# Altium schematic sheet cree_module.SchDoc
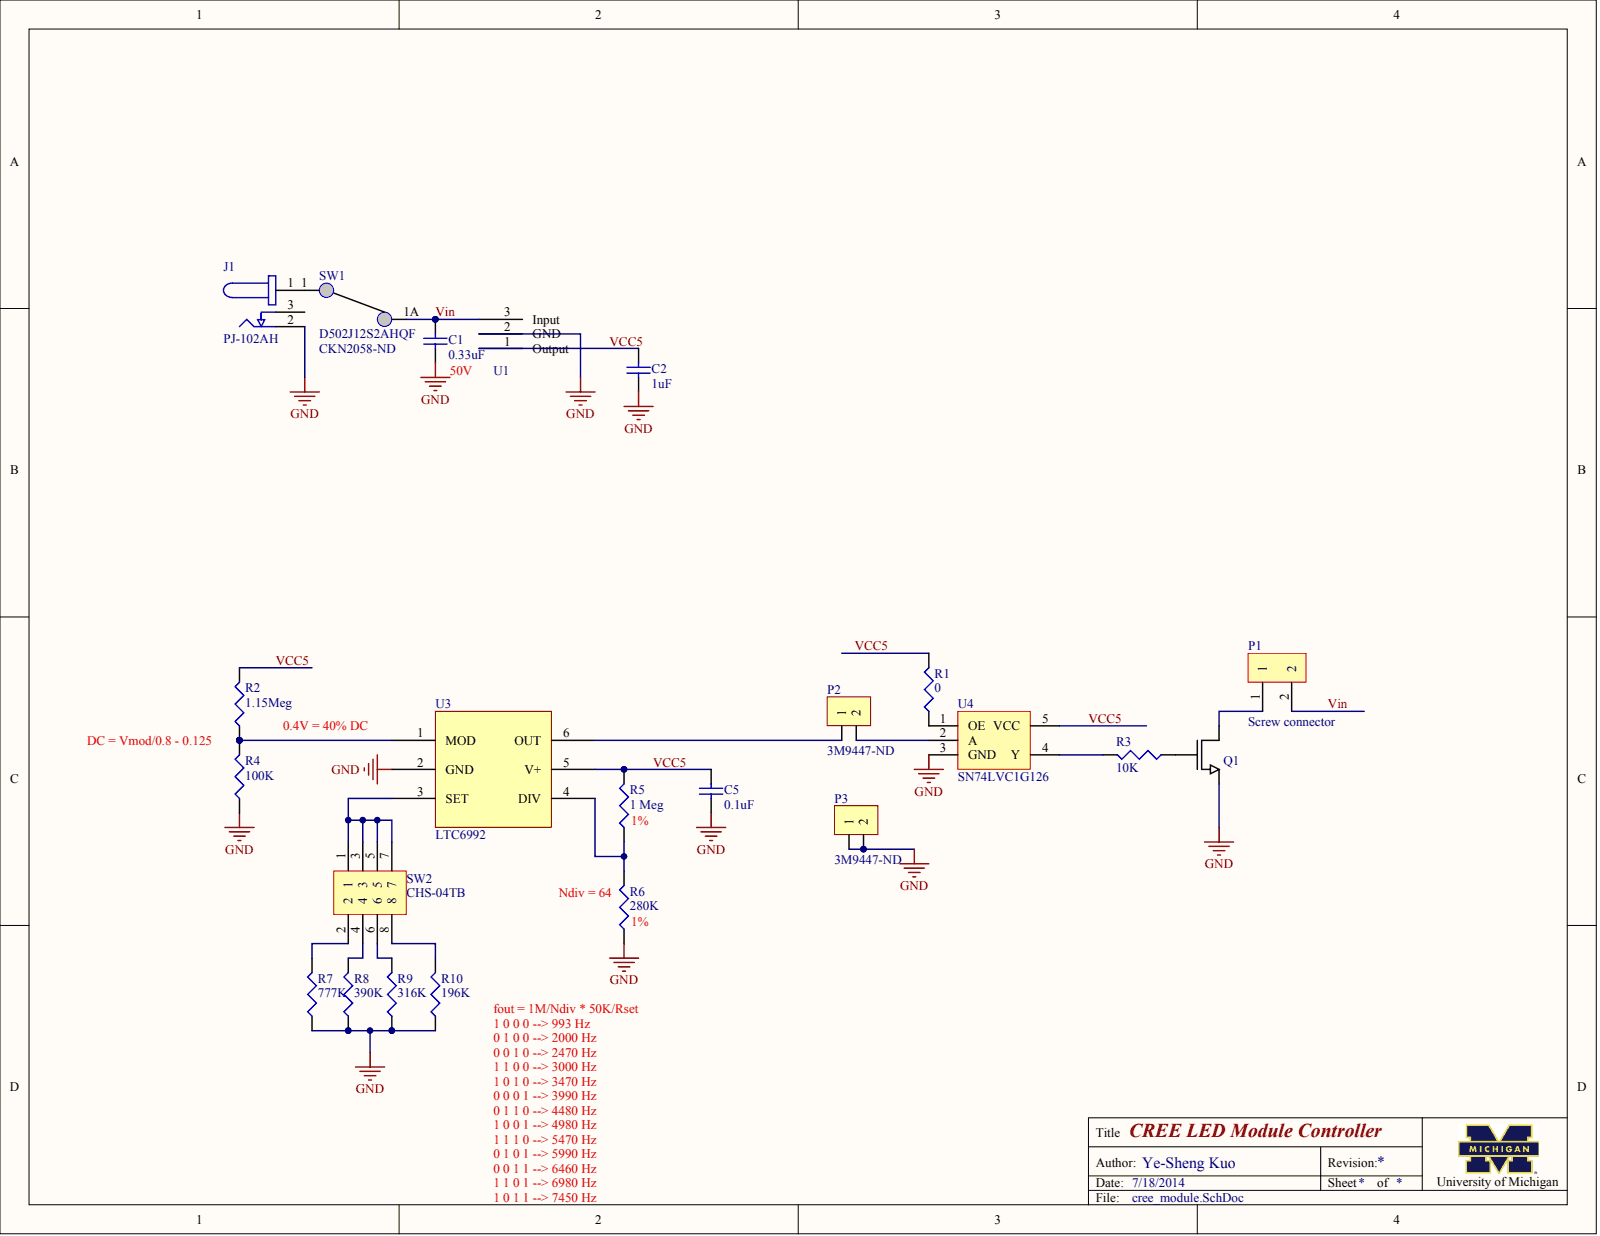
[diagram p0: cree_module.SchDoc]

## Parts
C1  value 0.33uF  (hidden comment: Cap Semi)
C2  value 1uF  (hidden comment: Cap Semi)
C5  value 0.1uF  (hidden comment: Cap Semi)
J1  PJ-102AH
P1  Screw connector
P2  Supplier Part Number 1: 3M9447-ND  (hidden comment: 1 x 2 header)
P3  Supplier Part Number 1: 3M9447-ND  (hidden comment: 1 x 2 header)
Q1  Si2312CDS  (value not drawn)
R1  value 0  (hidden comment: Res3)
R2  value 1.15Meg  (hidden comment: Res3)
R3  value 10K  (hidden comment: Res3)
R4  value 100K  (hidden comment: Res3)
R5  value 1 Meg  (hidden comment: Res3)
R6  value 280K  (hidden comment: Res3)
R7  value 777K  (hidden comment: Res3)
R8  value 390K  (hidden comment: Res3)
R9  value 316K  (hidden comment: Res3)
R10  value 196K  (hidden comment: Res3)
SW1  D502J12S2AHQF  Supplier Part Number 1: CKN2058-ND
SW2  CHS-04TB
U1  LM78L05  (value not drawn)
U3  LTC6992
U4  SN74LVC1G126

## Nets
GND: C1.1 C2.1 C5.1 J1.2 P3.1 P3.2 Q1.2 R4.1 R6.1 R7.1 R8.1 R9.1 R10.1 U1.2 U3.2(GND) U4.3(GND)
VCC5: C2.2 C5.2 R1.2 R2.2 R5.2 U1.1 U3.5(V+) U4.5(VCC)
VIN: C1.2 P1.2 SW1.1A U1.3
NetJ1_1: J1.1 SW1.1
NetP1_1: P1.1 Q1.3
NetP2_1: P2.1 U3.6(OUT)
NetP2_2: P2.2 U4.2(A)
NetQ1_1: Q1.1 R3.1
NetR1_1: R1.1 U4.1(OE)
NetR2_1: R2.1 R4.2 U3.1(MOD)
NetR3_2: R3.2 U4.4(Y)
NetR5_1: R5.1 R6.2 U3.4(DIV)
NetR7_2: R7.2 SW2.2
NetR8_2: R8.2 SW2.4
NetR9_2: R9.2 SW2.6
NetR10_2: R10.2 SW2.8
NetSW2_1: SW2.1 SW2.3 SW2.5 SW2.7 U3.3(SET)

Not connected: J1 3

## Library data of the parts
C1 C2 C5 J1 P1-P3 Q1 R1-R10 SW1 SW2 U1 U3 U4 = (no symbol name)
  C1 C2 C5: Published: 8-Jun-2000; LatestRevisionDate: 29-May-2009; LatestRevisionNote: IPC-7351 Footprint Added.; PackageReference: C1206; PackageDescription: Chip Capacitor
  J1: Supplier 1: Digi-Key; Supplier Part Number 1: CP-102AH-ND
  P1: Supplier 1: Digi-Key; Supplier Part Number 1: 277-1273-ND
  P2 P3 SW1: Supplier 1: Digi-Key
  Q1: Supplier 1: Digi-Key; Supplier Part Number 1: SI2312CDS-T1-GE3DKR-ND
  R1-R10: Published: 8-Jun-2000; LatestRevisionDate: 29-May-2009; LatestRevisionNote: IPC-7351 Footprint Added.; PackageReference: J1-0603; PackageDescription: Chip Resistor
  U1: Supplier 1: Digi-Key; Supplier Part Number 1: LM78L05ACZNS/NOPB-ND
  U3: Supplier 1: Digi-Key; Supplier Part Number 1: LTC6992CS6-1#TRMPBFTR-ND
  U4: Supplier 1: Digi-Key; Supplier Part Number 1: 296-11605-1-ND
  C1 C2 C5 R1-R10: Publisher: Altium Limited
